# Altium schematic sheet 2_LEDs_rA0.SchDoc
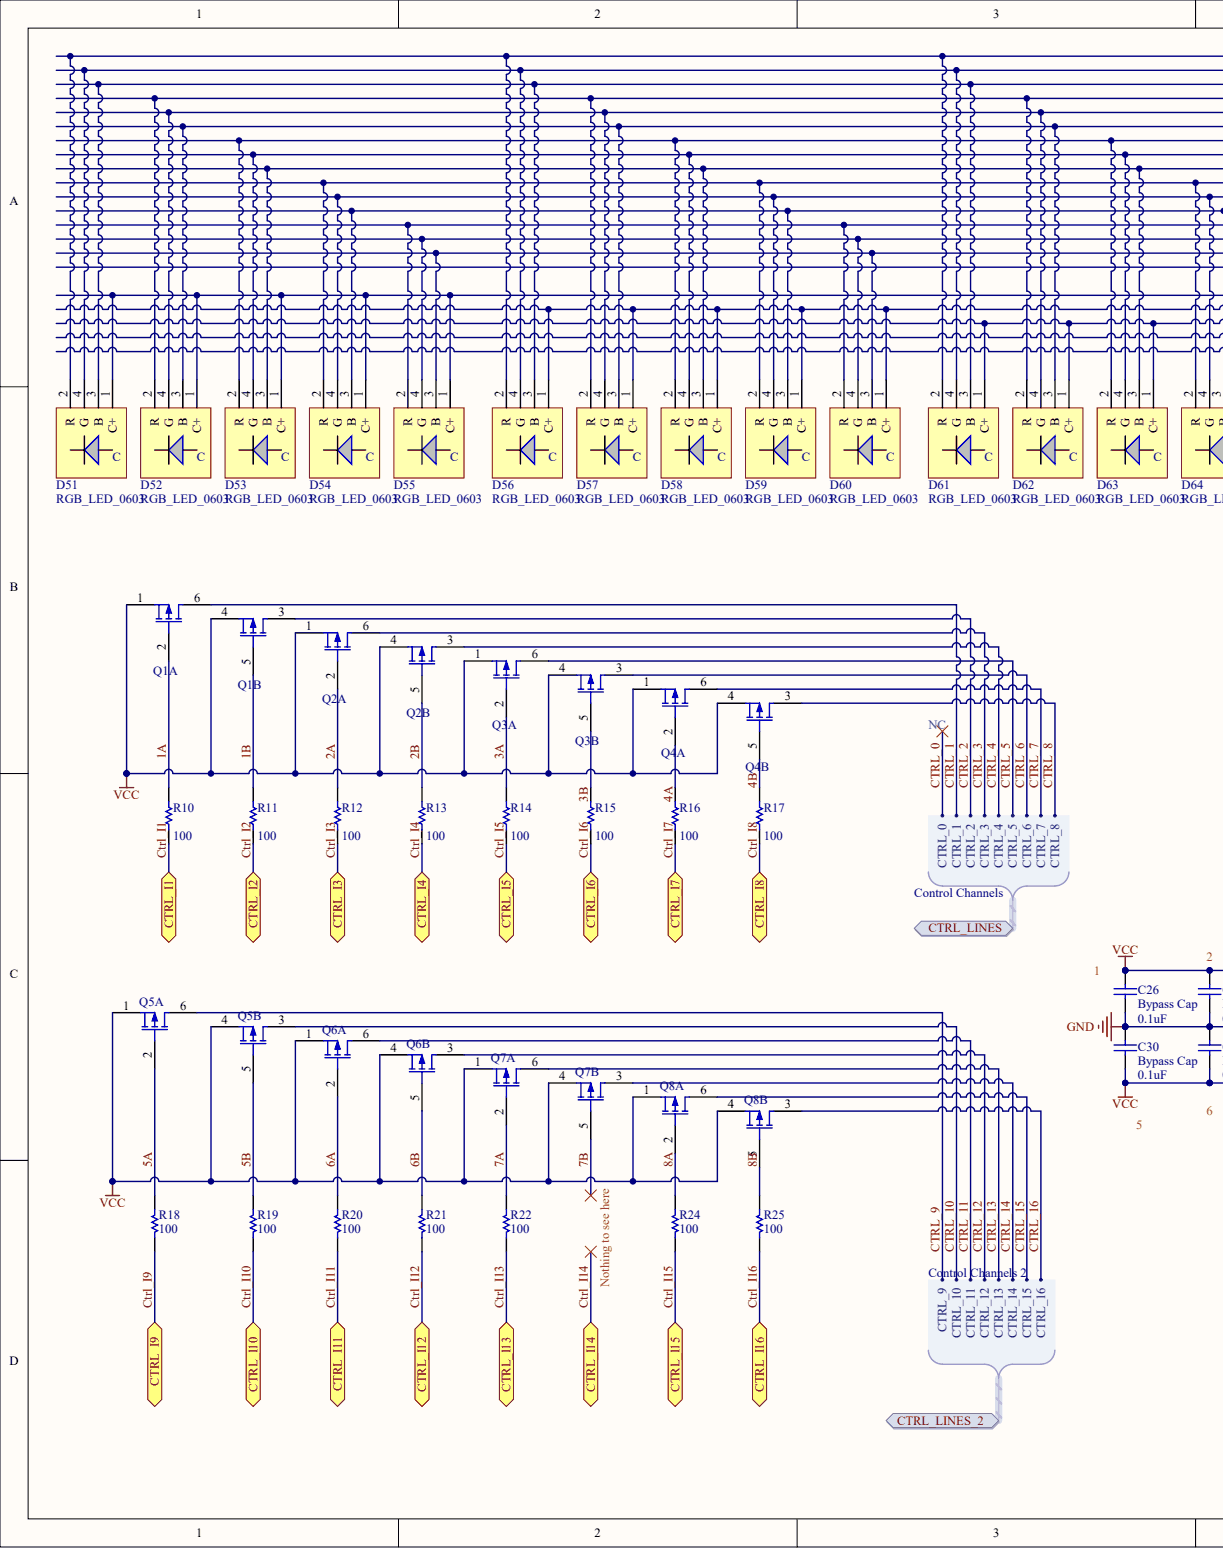
[diagram p0: 2_LEDs_rA0.SchDoc, left]
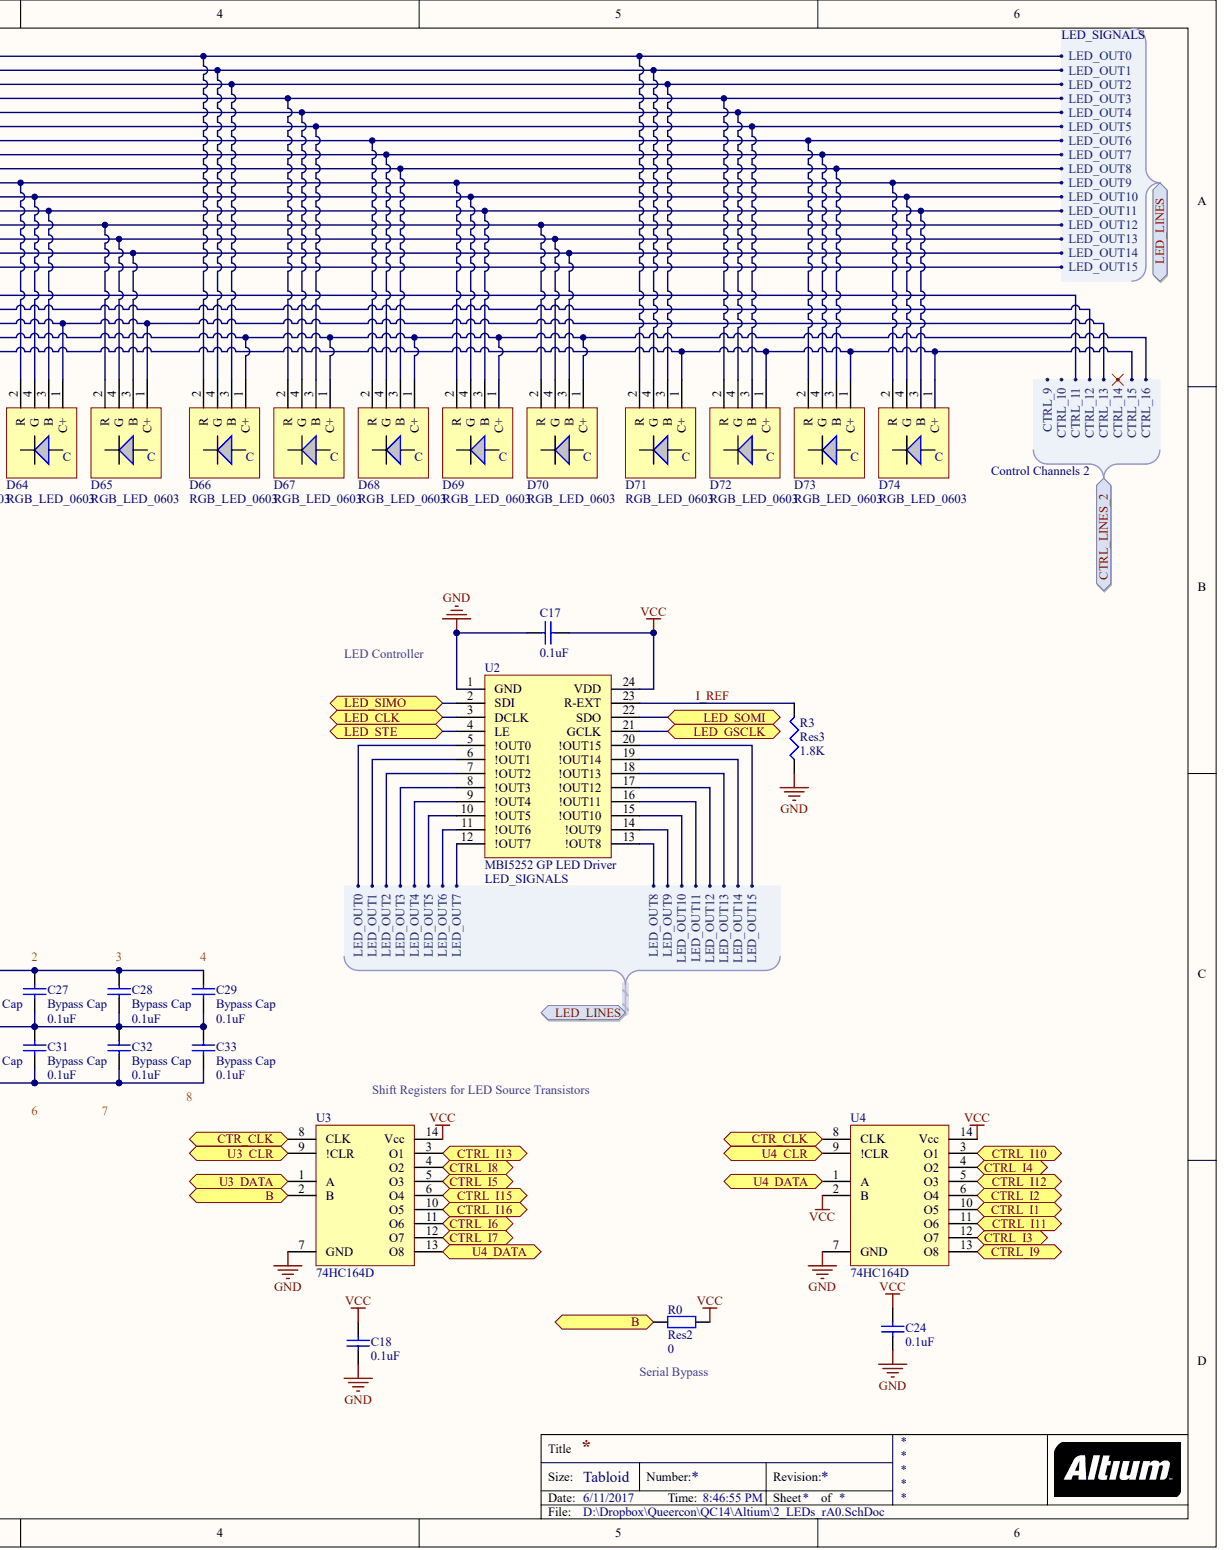
[diagram p1: 2_LEDs_rA0.SchDoc, right]

## Parts
C17  value 0.1uF  (hidden comment: Cap)
C18  value 0.1uF  (hidden comment: Cap)
C24  value 0.1uF  (hidden comment: Cap)
C26  Bypass Cap  value 0.1uF
C27  Bypass Cap  value 0.1uF
C28  Bypass Cap  value 0.1uF
C29  Bypass Cap  value 0.1uF
C30  Bypass Cap  value 0.1uF
C31  Bypass Cap  value 0.1uF
C32  Bypass Cap  value 0.1uF
C33  Bypass Cap  value 0.1uF
D51  RGB_LED_0603
D52  RGB_LED_0603
D53  RGB_LED_0603
D54  RGB_LED_0603
D55  RGB_LED_0603
D56  RGB_LED_0603
D57  RGB_LED_0603
D58  RGB_LED_0603
D59  RGB_LED_0603
D60  RGB_LED_0603
D61  RGB_LED_0603
D62  RGB_LED_0603
D63  RGB_LED_0603
D64  RGB_LED_0603
D65  RGB_LED_0603
D66  RGB_LED_0603
D67  RGB_LED_0603
D68  RGB_LED_0603
D69  RGB_LED_0603
D70  RGB_LED_0603
D71  RGB_LED_0603
D72  RGB_LED_0603
D73  RGB_LED_0603
D74  RGB_LED_0603
Q1  PCH MOSFET  (value not drawn)  (parts A,B)
Q2  PCH MOSFET  (value not drawn)  (parts A,B)
Q3  PCH MOSFET  (value not drawn)  (parts A,B)
Q4  PCH MOSFET  (value not drawn)  (parts A,B)
Q5  PCH MOSFET  (value not drawn)  (parts A,B)
Q6  PCH MOSFET  (value not drawn)  (parts A,B)
Q7  PCH MOSFET  (value not drawn)  (parts A,B)
Q8  PCH MOSFET  (value not drawn)  (parts A,B)
R0  Res2  value 0
R3  Res3  value 1.8K
R10  value 100
R11  value 100
R12  value 100
R13  value 100
R14  value 100
R15  value 100
R16  value 100
R17  value 100
R18  value 100  (hidden comment: Res1)
R19  value 100  (hidden comment: Res1)
R20  value 100  (hidden comment: Res1)
R21  value 100  (hidden comment: Res1)
R22  value 100  (hidden comment: Res1)
R24  value 100  (hidden comment: Res1)
R25  value 100  (hidden comment: Res1)
U2  MBI5252 GP LED Driver
U3  74HC164D
U4  74HC164D

## Ports
B, CTR_CLK, CTRL_I1, CTRL_I2, CTRL_I3, CTRL_I4, CTRL_I5, CTRL_I6, CTRL_I7, CTRL_I8, CTRL_I9, CTRL_I10, CTRL_I11, CTRL_I12, CTRL_I13, CTRL_I14, CTRL_I15, CTRL_I16, CTRL_LINES, CTRL_LINES.CTRL_0, CTRL_LINES.CTRL_1, CTRL_LINES.CTRL_2, CTRL_LINES.CTRL_3, CTRL_LINES.CTRL_4, CTRL_LINES.CTRL_5, CTRL_LINES.CTRL_6, CTRL_LINES.CTRL_7, CTRL_LINES.CTRL_8, CTRL_LINES_2, CTRL_LINES_2.CTRL_9, CTRL_LINES_2.CTRL_10, CTRL_LINES_2.CTRL_11, CTRL_LINES_2.CTRL_12, CTRL_LINES_2.CTRL_13, CTRL_LINES_2.CTRL_14, CTRL_LINES_2.CTRL_15, CTRL_LINES_2.CTRL_16, LED_CLK, LED_GSCLK, LED_LINES, LED_LINES.LED_OUT0, LED_LINES.LED_OUT1, LED_LINES.LED_OUT2, LED_LINES.LED_OUT3, LED_LINES.LED_OUT4, LED_LINES.LED_OUT5, LED_LINES.LED_OUT6, LED_LINES.LED_OUT7, LED_LINES.LED_OUT8, LED_LINES.LED_OUT9, LED_LINES.LED_OUT10, LED_LINES.LED_OUT11, LED_LINES.LED_OUT12, LED_LINES.LED_OUT13, LED_LINES.LED_OUT14, LED_LINES.LED_OUT15, LED_SIMO, LED_SOMI, LED_STE, U3_CLR, U3_DATA, U4_CLR, U4_DATA

## Nets
GND: C17.2 C18.2 C24.2 C26.1 C27.1 C28.1 C29.2 C30.1 C31.1 C32.1 C33.1 R3.1 U2.1(GND) U3.7(GND) U4.7(GND)
VCC: C17.1 C18.1 C24.1 C26.2 C27.2 C28.2 C29.1 C30.2 C31.2 C32.2 C33.2 Q1.1 Q1.4 Q2.1 Q2.4 Q3.1 Q3.4 Q4.1 Q4.4 Q5.1 Q5.4 Q6.1 Q6.4 Q7.1 Q7.4 Q8.1 Q8.4 R0.2 U2.24(VDD) U3.14(Vcc) U4.2(B) U4.14(Vcc)
1A: Q1.2 R10.2
1B: Q1.5 R11.2
2A: Q2.2 R12.2
2B: Q2.5 R13.2
3A: Q3.2 R14.2
4B: Q4.5 R17.2
5A: Q5.2 R18.2
5B: Q5.5 R19.2
6A: Q6.2 R20.2
6B: Q6.5 R21.2
7A: Q7.2 R22.2
7B: Q7.5
8A: Q8.2 R24.2
8B: Q8.5 R25.2
B: R0.1 U3.2(B) ; port B
CTR_CLK: U3.8(CLK) U4.8(CLK) ; port CTR_CLK
CTRL_1: Q1.6 ; port CTRL_LINES
CTRL_2: Q1.3 ; port CTRL_LINES
CTRL_3: Q2.6 ; port CTRL_LINES
CTRL_4: Q2.3 ; port CTRL_LINES
CTRL_5: Q3.6 ; port CTRL_LINES
CTRL_6: Q3.3 ; port CTRL_LINES
CTRL_7: Q4.6 ; port CTRL_LINES
CTRL_8: Q4.3 ; port CTRL_LINES
CTRL_9: Q5.6 ; port CTRL_LINES_2
CTRL_10: Q5.3 ; port CTRL_LINES_2
CTRL_11: D51.1(C+) D52.1(C+) D53.1(C+) D54.1(C+) D55.1(C+) Q6.6 ; port CTRL_LINES_2
CTRL_12: D56.1(C+) D57.1(C+) D58.1(C+) D59.1(C+) D60.1(C+) Q6.3 ; port CTRL_LINES_2
CTRL_13: D61.1(C+) D62.1(C+) D63.1(C+) D64.1(C+) D65.1(C+) Q7.6 ; port CTRL_LINES_2
CTRL_14: Q7.3 ; port CTRL_LINES_2
CTRL_15: D71.1(C+) D72.1(C+) D73.1(C+) D74.1(C+) Q8.6 ; port CTRL_LINES_2
CTRL_16: D66.1(C+) D67.1(C+) D68.1(C+) D69.1(C+) D70.1(C+) Q8.3 ; port CTRL_LINES_2
CTRL_I1: R10.1 U4.10(O5) ; port CTRL_I1
CTRL_I2: R11.1 U4.6(O4) ; port CTRL_I2
CTRL_I3: R12.1 U4.12(O7) ; port CTRL_I3
CTRL_I4: R13.1 U4.4(O2) ; port CTRL_I4
CTRL_I5: R14.1 U3.5(O3) ; port CTRL_I5
CTRL_I6: R15.1 U3.11(O6) ; port CTRL_I6
CTRL_I7: R16.1 U3.12(O7) ; port CTRL_I7
CTRL_I8: R17.1 U3.4(O2) ; port CTRL_I8
CTRL_I9: R18.1 U4.13(O8) ; port CTRL_I9
CTRL_I10: R19.1 U4.3(O1) ; port CTRL_I10
CTRL_I11: R20.1 U4.11(O6) ; port CTRL_I11
CTRL_I12: R21.1 U4.5(O3) ; port CTRL_I12
CTRL_I13: R22.1 U3.3(O1) ; port CTRL_I13
CTRL_I15: R24.1 U3.6(O4) ; port CTRL_I15
CTRL_I16: R25.1 U3.10(O5) ; port CTRL_I16
I_REF: R3.2 U2.23(R-EXT)
LED_CLK: U2.3(DCLK) ; port LED_CLK
LED_GSCLK: U2.21(GCLK) ; port LED_GSCLK
LED_SIMO: U2.2(SDI) ; port LED_SIMO
LED_SOMI: U2.22(SDO) ; port LED_SOMI
LED_STE: U2.4(LE) ; port LED_STE
U3_CLR: U3.9(!CLR) ; port U3_CLR
U3_DATA: U3.1(A) ; port U3_DATA
U4_CLR: U4.9(!CLR) ; port U4_CLR
U4_DATA: U3.13(O8) U4.1(A) ; port U4_DATA
NetD51_2: D51.2(R) D56.2(R) D61.2(R) D66.2(R) D71.2(R) U2.5(!OUT0) ; port LED_LINES
NetD51_3: D51.3(B) D56.3(B) D61.3(B) D66.3(B) D71.3(B) U2.7(!OUT2) ; port LED_LINES
NetD51_4: D51.4(G) D56.4(G) D61.4(G) D66.4(G) D71.4(G) U2.6(!OUT1) ; port LED_LINES
NetD52_2: D52.2(R) D57.2(R) D62.2(R) D67.2(R) D72.2(R) U2.8(!OUT3) ; port LED_LINES
NetD52_3: D52.3(B) D57.3(B) D62.3(B) D67.3(B) D72.3(B) U2.10(!OUT5) ; port LED_LINES
NetD52_4: D52.4(G) D57.4(G) D62.4(G) D67.4(G) D72.4(G) U2.9(!OUT4) ; port LED_LINES
NetD53_2: D53.2(R) D58.2(R) D63.2(R) D68.2(R) D73.2(R) U2.11(!OUT6) ; port LED_LINES
NetD53_3: D53.3(B) D58.3(B) D63.3(B) D68.3(B) D73.3(B) U2.13(!OUT8) ; port LED_LINES
NetD53_4: D53.4(G) D58.4(G) D63.4(G) D68.4(G) D73.4(G) U2.12(!OUT7) ; port LED_LINES
NetD54_2: D54.2(R) D59.2(R) D64.2(R) D69.2(R) D74.2(R) U2.14(!OUT9) ; port LED_LINES
NetD54_3: D54.3(B) D59.3(B) D64.3(B) D69.3(B) D74.3(B) U2.16(!OUT11) ; port LED_LINES
NetD54_4: D54.4(G) D59.4(G) D64.4(G) D69.4(G) D74.4(G) U2.15(!OUT10) ; port LED_LINES
NetD55_2: D55.2(R) D60.2(R) D65.2(R) D70.2(R) U2.17(!OUT12) ; port LED_LINES
NetD55_3: D55.3(B) D60.3(B) D65.3(B) D70.3(B) U2.19(!OUT14) ; port LED_LINES
NetD55_4: D55.4(G) D60.4(G) D65.4(G) D70.4(G) U2.18(!OUT13) ; port LED_LINES
NetQ3_5: Q3.5 R15.2
NetQ4_2: Q4.2 R16.2
NetU2_20: U2.20(!OUT15) ; port LED_LINES
3B:
4A:
CTRL_0: ; port CTRL_LINES
CTRL_I14: ; port CTRL_I14

## Library data of the parts
C17 C18 C24 C26-C33 D51-D74 Q1-Q8 R0 R3 R10-R22 R24 R25 U2-U4 = (no symbol name)
  C17 C18 C24 C26-C33: Published: 8-Jun-2000; LatestRevisionDate: 17-Jul-2002; LatestRevisionNote: Re-released for DXP Platform.; PackageReference: RAD-0.3; PackageDescription: Radial Cap, Thru-Hole; 2 Leads; 0.3 in Pin Spacing
  R0: Published: 8-Jun-2000; LatestRevisionDate: 17-Jul-2002; LatestRevisionNote: Re-released for DXP Platform.; PackageReference: AXIAL-0.4; PackageDescription: Axial Device, Thru-Hole; 2 Leads; 0.4 in Pin Spacing
  R3: Published: 8-Jun-2000; LatestRevisionDate: 29-May-2009; LatestRevisionNote: IPC-7351 Footprint Added.; PackageReference: J1-0603; PackageDescription: Chip Resistor
  R10-R22 R24 R25: Published: 8-Jun-2000; LatestRevisionDate: 17-Jul-2002; LatestRevisionNote: Re-released for DXP Platform.; PackageReference: AXIAL-0.3; PackageDescription: Axial Device, Thru-Hole; 2 Leads; 0.3 in Pin Spacing
  C17 C18 C24 C26-C33 R0 R3 R10-R22 R24 R25: Publisher: Altium Limited
